# Altium schematic sheet mpu6050.SchDoc
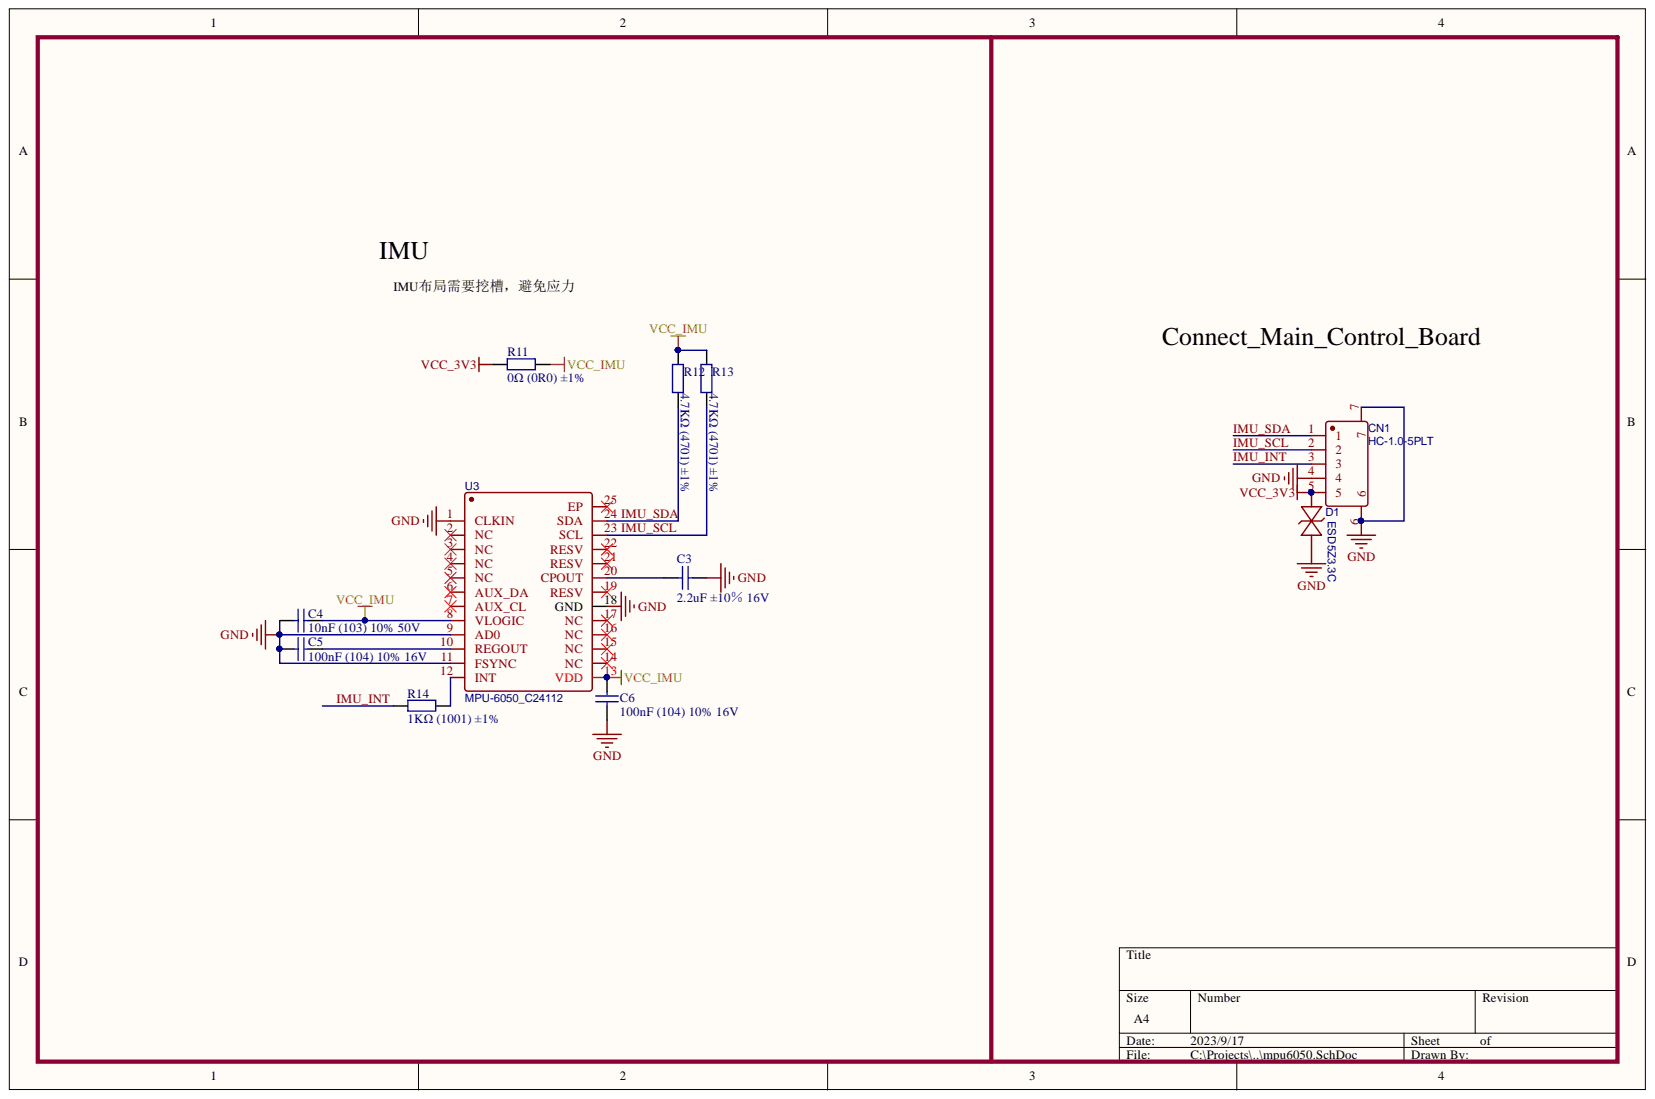
[diagram p0: mpu6050.SchDoc]

## Parts
C3  2.2uF ±10％ 16V  [0402_C]
C4  10nF (103) 10% 50V  [0402_C]
C5  100nF (104) 10% 16V  [0402_C]
C6  100nF (104) 10% 16V  [0402_C]
CN1  HC-1.0-5PLT  [CONN-SMD_5P-P1.00_HCTL_HC-1.0-5PLT]
D1  ESD5Z3.3C  [SOD-523_L1.2-W0.8-LS1.6-BI]
R11  0Ω (0R0) ±1%  [0402_R]
R12  4.7KΩ (4701) ±1%  [0402_R]
R13  4.7KΩ (4701) ±1%  [0402_R]
R14  1KΩ (1001) ±1%  [0402_R]
U3  MPU-6050_C24112  [QFN-24_L4.0-W4.0-P0.50-BL-EP2.6]

## Nets
GND: C3.1 C4.2 C5.1 C6.1 CN1.4 CN1.6 CN1.7 D1.2 U3.1(CLKIN) U3.9(AD0) U3.11(FSYNC) U3.18(GND)
VCC_3V3: CN1.5 D1.1 R11.1
VCC_IMU: C4.1 C6.2 R11.2 R12.2 R13.2 U3.8(VLOGIC) U3.13(VDD)
IMU_INT: CN1.3 R14.1
IMU_SCL: CN1.2 R13.1 U3.23(SCL)
IMU_SDA: CN1.1 R12.1 U3.24(SDA)
NetC3_2: C3.2 U3.20(CPOUT)
NetC5_2: C5.2 U3.10(REGOUT)
NetR14_2: R14.2 U3.12(INT)

Not connected: U3 2(NC) 3(NC) 4(NC) 5(NC) 6(AUX_DA) 7(AUX_CL) 14(NC) 15(NC) 16(NC) 17(NC) 19(RESV) 21(RESV) 22(RESV) 25(EP)

## Library data of the parts
C3 C5 C6 = 0402 100nF (104) 10% 16V: 贴片电容; Component Kind: Standard; ComponentLink1Description: 供应商链接; ComponentLink1URL: http://www.szlcsc.com/product/details_1877.html; Library Name: Miscellaneous Devices LC.IntLib; Package: 0402; Supplier: LC; SuppliersPartNumber: C1525
C4 = 0402 10nF (103) 10% 50V: 贴片电容; Component Kind: Standard; ComponentLink1Description: 供应商链接; ComponentLink1URL: http://www.szlcsc.com/product/details_15869.html; Library Name: Miscellaneous Devices LC.IntLib; Package: 0402; Supplier: LC; SuppliersPartNumber: C15195
CN1 = HC-1.0-5PLT: BOM_Manufacturer: HCTL(华灿天禄); Contributor: LCSC; BOM_JLCPCB Part Class: Extended Part; BOM_Manufacturer Part: HC-1.0-5PLT; BOM_Supplier: LCSC; BOM_Supplier Part: C2845373; Component Kind: Standard; spicePre: C; spiceSymbolName: HC-1.0-5PLT; Library Name: chaoning_Integrated_Library.IntLib
D1 = ESD5Z3.3C: BOM_Manufacturer: MDD（Microdiode Electronics）; Contributor: LCSC; BOM_JLCPCB Part Class: Extended Part; BOM_Manufacturer Part: ESD5Z3.3C; BOM_Supplier: LCSC; BOM_Supplier Part: C502551; Component Kind: Standard; spicePre: D; spiceSymbolName: ESD5Z3.3C; Library Name: chaoning_Integrated_Library.IntLib
R11 = 0402 0Ω (0R0) 1%: 贴片电阻; Component Kind: Standard; ComponentLink1Description: 供应商链接; ComponentLink1URL: http://www.szlcsc.com/product/details_17853.html; Library Name: Miscellaneous Devices LC.IntLib; Package: 0402; Supplier: LC; SuppliersPartNumber: C17168
R12 R13 = 0402 4.7KΩ (4701) 1%: 贴片电阻; Component Kind: Standard; ComponentLink1Description: 供应商链接; ComponentLink1URL: http://www.szlcsc.com/product/details_26643.html; Library Name: Miscellaneous Devices LC.IntLib; Package: 0402; Supplier: LC; SuppliersPartNumber: C25900
R14 = 0402 1KΩ (1001) 1%: 贴片电阻; Component Kind: Standard; ComponentLink1Description: 供应商链接; ComponentLink1URL: http://www.szlcsc.com/product/details_12256.html; Library Name: Miscellaneous Devices LC.IntLib; Package: 0402; Supplier: LC; SuppliersPartNumber: C11702
U3 = MPU-6050_C24112: BOM_Manufacturer: InvenSense; sourceId: 1T5FDZYC8; BOM_JLCPCB Part Class: Basic Part; BOM_Manufacturer Part: MPU-6050; BOM_Supplier: LCSC; BOM_Supplier Part: C24112; Component Kind: Standard; spicePre: U; spiceSymbolName: MPU-6050_C24112; timeStamp: 1462265206; Library Name: chaoning_Integrated_Library.IntLib
